# Altium schematic sheet Power Layer v1.2.SchDoc
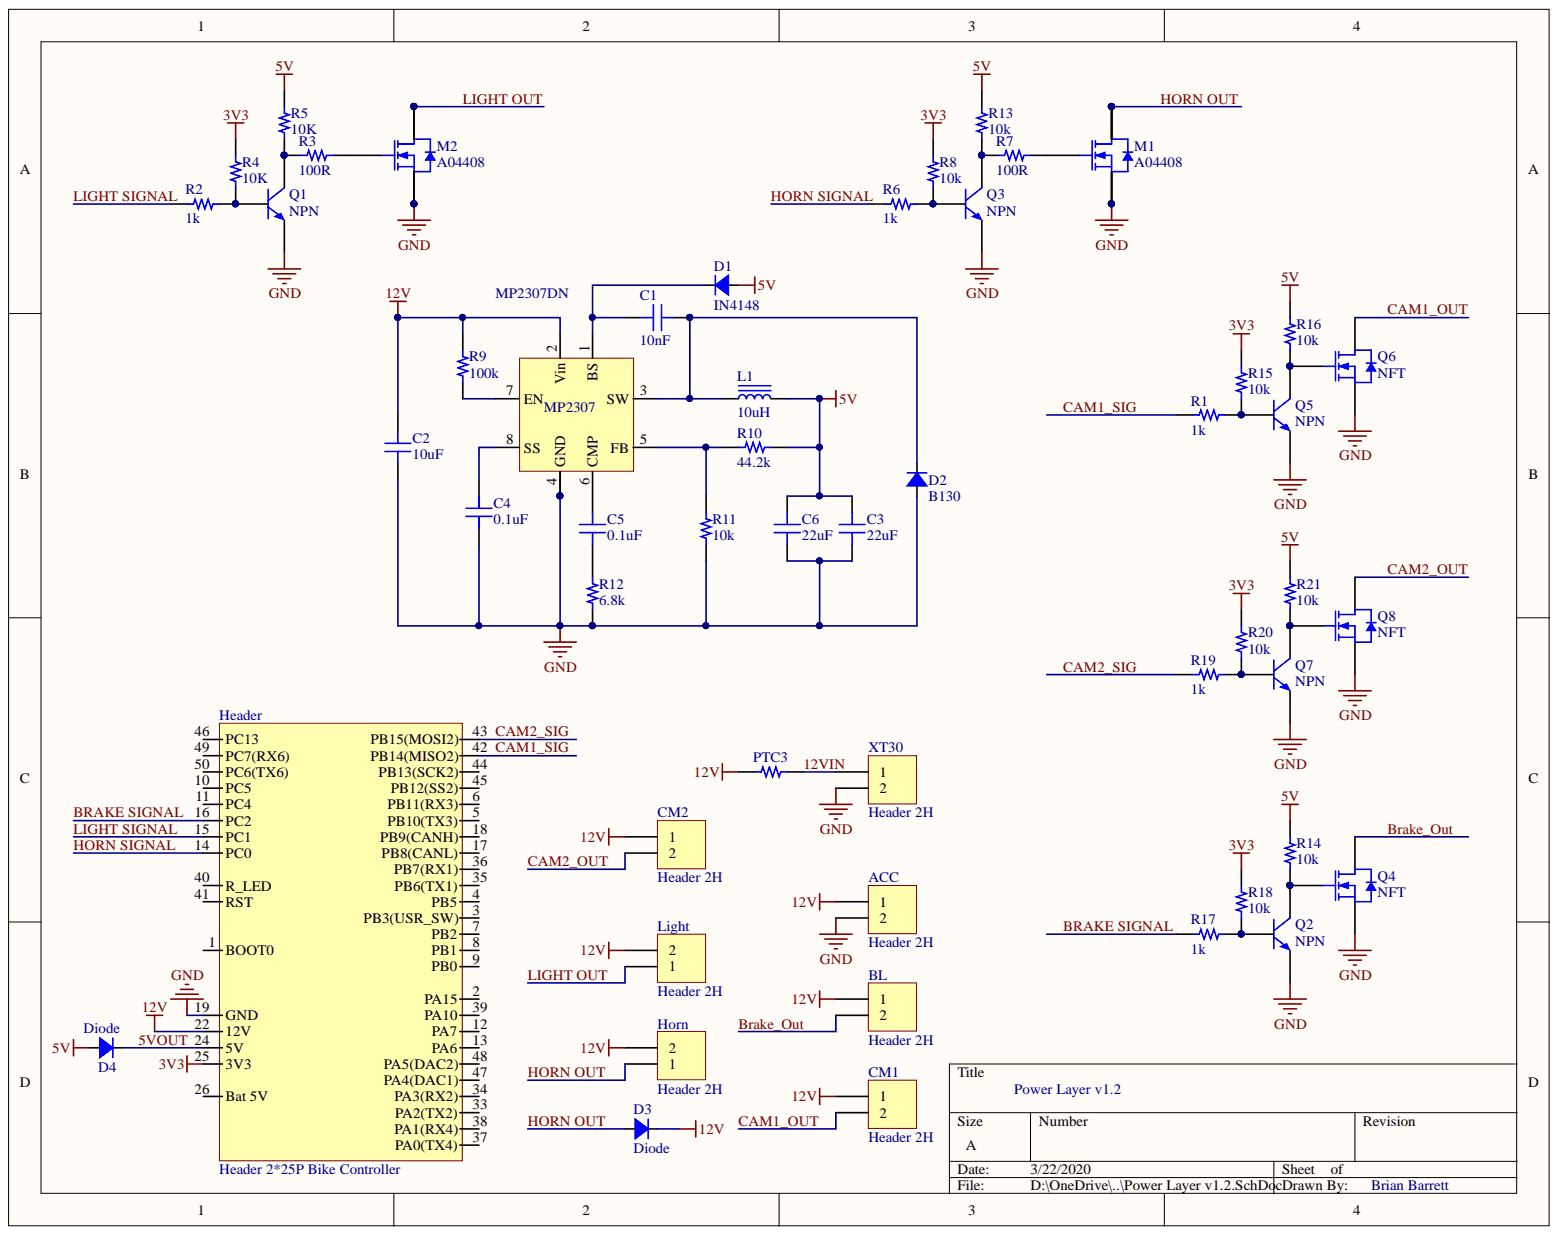
[diagram p0: Power Layer v1.2.SchDoc]

## Parts
ACC  Header 2H  [SH 1.0 * 2]
BL  Header 2H  [SH 1.0 * 2]
C1  10nF  [CAP 0603/1608 RF]
C2  10uF  [RES 0805/2012 RF Min]
C3  22uF  [CAP 0805/2012 RF]
C4  0.1uF  [CAP 0603/1608 RF]
C5  0.1uF  [CAP 0603/1608 RF]
C6  22uF  [CAP 0805/2012 RF]
CM1  Header 2H  [SH 1.0 * 2]
CM2  Header 2H  [SH 1.0 * 2]
D1  IN4148  [SOD-123F]
D2  B130  [SOD-123F]
D3  Diode  [SMA]
D4  Diode  [SMA]
Header  Header 2*25P Bike Controller  [1.0mm 2X25 Header - Dbl Layer]
Horn  Header 2H  [EH 2P]
L1  10uH  [IND 4x4 2]
Light  Header 2H  [EH 2P]
M1  A04408  [SOIC8]
M2  A04408  [SOIC8]
MP2307  MP2307DN  [SOIC8N]
PTC3  (no value)  [CAP 1210/3225 - 1.2MM]
Q1  NPN  [SOT-23]
Q2  NPN  [SOT-23]
Q3  NPN  [SOT-23]
Q4  NFT  [SOT-23]
Q5  NPN  [SOT-23]
Q6  NFT  [SOT-23]
Q7  NPN  [SOT-23]
Q8  NFT  [SOT-23]
R1  1k  [CAP 0603/1608 RF]
R2  1k  [CAP 0603/1608 RF]
R3  100R  [RES 0805/2012 RF]
R4  10K  [CAP 0603/1608 RF]
R5  10K  [CAP 0603/1608 RF]
R6  1k  [CAP 0603/1608 RF]
R7  100R  [RES 0805/2012 RF]
R8  10k  [CAP 0603/1608 RF]
R9  100k  [CAP 0603/1608 RF]
R10  44.2k  [CAP 0603/1608 RF]
R11  10k  [CAP 0603/1608 RF]
R12  6.8k  [CAP 0603/1608 RF]
R13  10k  [CAP 0603/1608 RF]
R14  10k  [CAP 0603/1608 RF]
R15  10k  [CAP 0603/1608 RF]
R16  10k  [CAP 0603/1608 RF]
R17  1k  [CAP 0603/1608 RF]
R18  10k  [CAP 0603/1608 RF]
R19  1k  [CAP 0603/1608 RF]
R20  10k  [CAP 0603/1608 RF]
R21  10k  [CAP 0603/1608 RF]
XT30  Header 2H  [XT30BW]

## Nets
3V3: Header.25(3V3) R4.2 R8.2 R15.2 R18.2 R20.2
5V: C3.2 C6.2 D1.1 D4.1 L1.2 R5.2 R10.1 R13.1 R14.2 R16.1 R21.2
12V: ACC.1 BL.1 C2.2 CM1.1 CM2.1 D3.2 Header.22(12V) Horn.2 Light.2 MP2307.2(Vin) PTC3.1 R9.2
GND: ACC.2 C2.1 C3.1 C4.1 C6.1 D2.1 Header.19(GND) M1.1 M1.2 M1.3 M2.1 M2.2 M2.3 MP2307.4(GND) MP2307.9 Q1.1 Q2.1 Q3.1 Q4.3 Q5.1 Q6.3 Q7.1 Q8.3 R11.1 R12.1 XT30.2
5VOUT: D4.2 Header.24(5V)
12VIN: PTC3.2 XT30.1
BRAKE SIGNAL: Header.16(PC2) R17.1
BRAKE_OUT: BL.2 Q4.4
CAM1_OUT: CM1.2 Q6.4
CAM1_SIG: Header.42(PB14(MISO2)) R1.1
CAM2_OUT: CM2.2 Q8.4
CAM2_SIG: Header.43(PB15(MOSI2)) R19.2
HORN OUT: D3.1 Horn.1 M1.5 M1.6 M1.7 M1.8
HORN SIGNAL: Header.14(PC0) R6.1
LIGHT OUT: Light.1 M2.5 M2.6 M2.7 M2.8
LIGHT SIGNAL: Header.15(PC1) R2.1
NetC1_1: C1.1 D2.2 L1.1 MP2307.3(SW)
NetC1_2: C1.2 D1.2 MP2307.1(BS)
NetC4_2: C4.2 MP2307.8(SS)
NetC5_1: C5.1 R12.2
NetC5_2: C5.2 MP2307.6(CMP)
NetM1_4: M1.4 R7.2
NetM2_4: M2.4 R3.2
NetMP2307_5: MP2307.5(FB) R10.2 R11.2
NetMP2307_7: MP2307.7(EN) R9.1
NetQ1_2: Q1.2 R2.2 R4.1
NetQ1_3: Q1.3 R3.1 R5.1
NetQ2_2: Q2.2 R17.2 R18.1
NetQ2_3: Q2.3 Q4.1 R14.1
NetQ3_2: Q3.2 R6.2 R8.1
NetQ3_3: Q3.3 R7.1 R13.2
NetQ5_2: Q5.2 R1.2 R15.1
NetQ5_3: Q5.3 Q6.1 R16.2
NetQ7_2: Q7.2 R19.1 R20.1
NetQ7_3: Q7.3 Q8.1 R21.1

Not connected: Header 1(BOOT0) 2(PA15) 3(PB3(USR_SW)) 4(PB5) 5(PB10(TX3)) 6(PB11(RX3)) 7(PB2) 8(PB1) 9(PB0) 10(PC5) 11(PC4) 12(PA7) 13(PA6) 17(PB8(CANL)) 18(PB9(CANH)) 26(Bat_5V) 33(PA2(TX2)) 34(PA3(RX2)) 35(PB6(TX1)) 36(PB7(RX1)) 37(PA0(TX4)) 38(PA1(RX4)) 39(PA10) 40(R_LED) 41(RST) 44(PB13(SCK2)) 45(PB12(SS2)) 46(PC13) 47(PA4(DAC1)) 48(PA5(DAC2)) 49(PC7(RX6)) 50(PC6(TX6))

## Library data of the parts
ACC BL CM1 CM2 Horn Light XT30 = Header 2H: Header, 2-Pin; Component Kind: Standard; LatestRevisionDate: 17-Jul-2002; LatestRevisionNote: Re-released for DXP Platform.; Library Name: BMI.SchLib; Signal Integrity: Connector; Publisher: Altium Limited
C1-C6 = Capacitor: SMT Capacitor; Component Kind: Standard; Library Name: Passives.SchLib
  C1: Value: 10nF
  C2: Value: 10uF
  C3 C6: Value: 22uF
  C4 C5: Value: 0.1uF
D1 D2 = Diode: Component Kind: Standard; Library Name: BMI.SchLib
Header = Header 2*25P Bike Controller: Header 50 Pin; Component Kind: Standard; LatestRevisionDate: 17-Jul-2002; LatestRevisionNote: Re-released for DXP Platform.; Library Name: BMI.SchLib; Publisher: Altium Limited
L1 = Inductor: SMT Inductor; Component Kind: Standard; Library Name: Passives.SchLib; Value: 10uH
M1 M2 = A04408: N-Channel MOSFET; Component Kind: Standard; Library Name: BMI.SchLib
PTC3 R1-R21 = Resistor: SMT Resistor; Component Kind: Standard; Library Name: Passives.SchLib
  R1 R2 R6 R17 R19: Value: 1k
  R3 R7: Value: 100R
  R4 R5: Value: 10K
  R8 R11 R13-R16 R18 R20 R21: Value: 10k
  R9: Value: 100k
  R10: Value: 44.2k
  R12: Value: 6.8k
Q1-Q3 Q5 Q7 = NPN: NPN Bipolar Transistor; Component Kind: Standard; LatestRevisionDate: 29-May-2009; LatestRevisionNote: IPC-7351 Footprint Added.; Library Name: BMI.SchLib; PackageDescription: 3-Pin SOT-23 Package 0.95 mm Pitch, 2.4 mm Lead Span; PackageReference: SOT-23B; PackageVersion: Aug-1999; Published: 8-Jun-2000; Signal Integrity: PNP; Simulation: PNP; Publisher: Altium Limited
Q4 Q6 Q8 = N MOSFET: N-Channel MOSFET; Component Kind: Standard; Library Name: BMI.SchLib
D3 D4 = Diode: Component Kind: Standard; Library Name: BMI.SchLib
MP2307 = MP2307DN: Component Kind: Standard; Library Name: BMI.SchLib
